# Altium schematic sheet Schematic.SchDoc
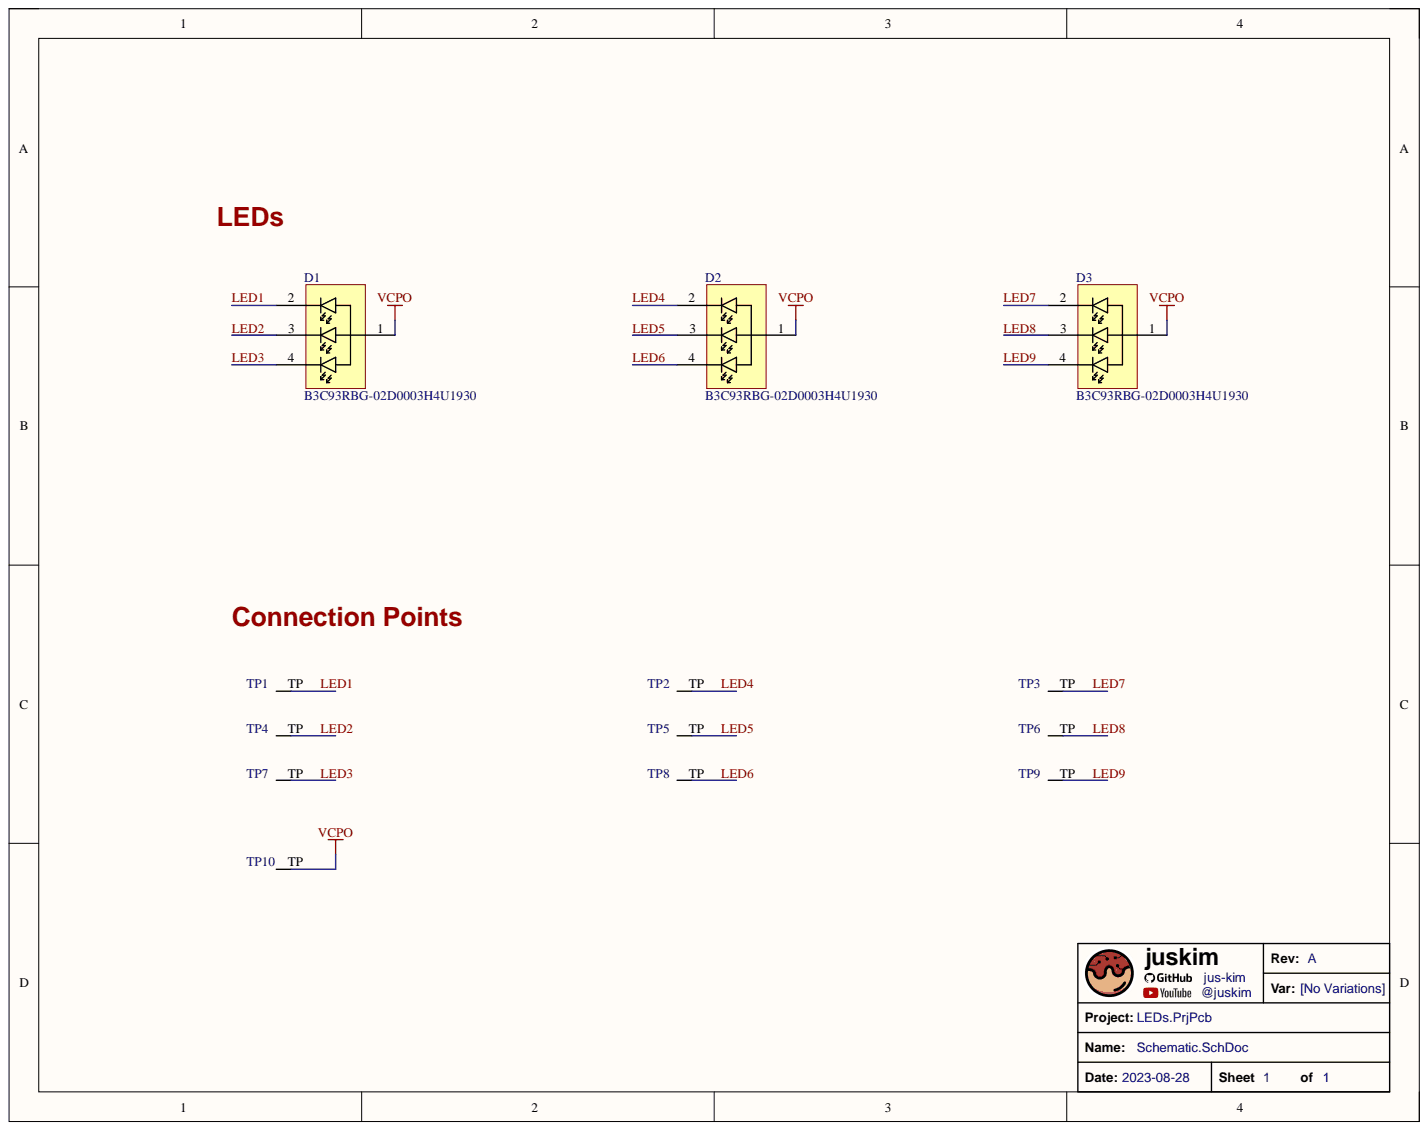
[diagram p0: Schematic.SchDoc]

## Parts
1  TitleBlock_juskim  (value not drawn)
D1  B3C93RBG-02D0003H4U1930  [0404]
D2  B3C93RBG-02D0003H4U1930  [0404]
D3  B3C93RBG-02D0003H4U1930  [0404]
TP1  Testpoint_1mm  [Testpoint_1mm]  (value not drawn)
TP2  Testpoint_1mm  [Testpoint_1mm]  (value not drawn)
TP3  Testpoint_1mm  [Testpoint_1mm]  (value not drawn)
TP4  Testpoint_1mm  [Testpoint_1mm]  (value not drawn)
TP5  Testpoint_1mm  [Testpoint_1mm]  (value not drawn)
TP6  Testpoint_1mm  [Testpoint_1mm]  (value not drawn)
TP7  Testpoint_1mm  [Testpoint_1mm]  (value not drawn)
TP8  Testpoint_1mm  [Testpoint_1mm]  (value not drawn)
TP9  Testpoint_1mm  [Testpoint_1mm]  (value not drawn)
TP10  Testpoint_1mm  [Testpoint_1mm]  (value not drawn)

## Nets
VCPO: D1.1 D2.1 D3.1 TP10.TP
LED1: D1.2 TP1.TP
LED2: D1.3 TP4.TP
LED3: D1.4 TP7.TP
LED4: D2.2 TP2.TP
LED5: D2.3 TP5.TP
LED6: D2.4 TP8.TP
LED7: D3.2 TP3.TP
LED8: D3.3 TP6.TP
LED9: D3.4 TP9.TP

## Library data of the parts
D1-D3 = LED0404: Red, Green, Blue (RGB) 622nm Red, 528nm Green, 469nm Blue LED Indication - Discrete 1.6V Red, 2.4V Green, 2.4V Blue 4-SMD, No Lead; Manufacturer: Harvatek Corporation; Component Kind: Standard; Library Name: MySchematics.SchLib; Link - DigiKey: https://www.digikey.ca/en/products/detail/harvatek-corporation/B3C93RBG-02D0003H4U1930/16729540; Link - Mouser: N/A
1 = TitleBlock_juskim: Component Kind: Standard; Library Name: juskim_SchLib.SchLib
TP1-TP10 = Testpoint_1mm: Component Kind: Standard; Library Name: MySchematics.SchLib
